# Altium schematic sheet a0141b0_CSS Moonlight AS7265x_scm01.SchDoc
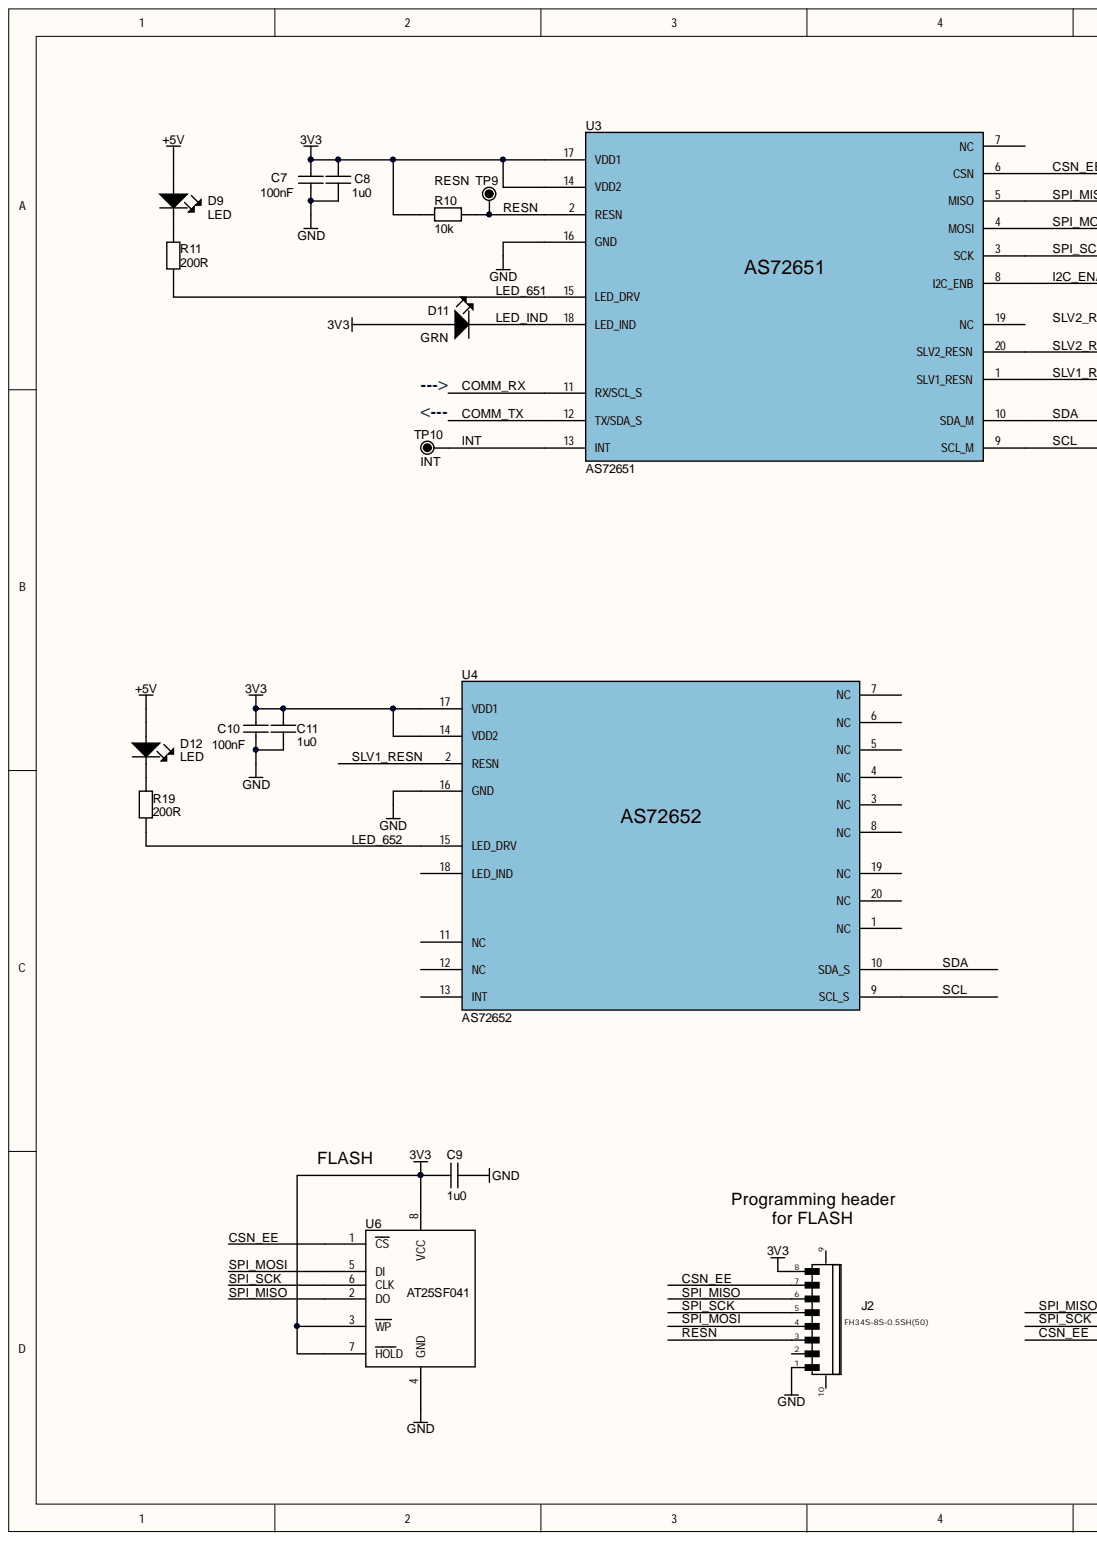
[diagram p0: a0141b0_CSS Moonlight AS7265x_scm01.SchDoc, left]
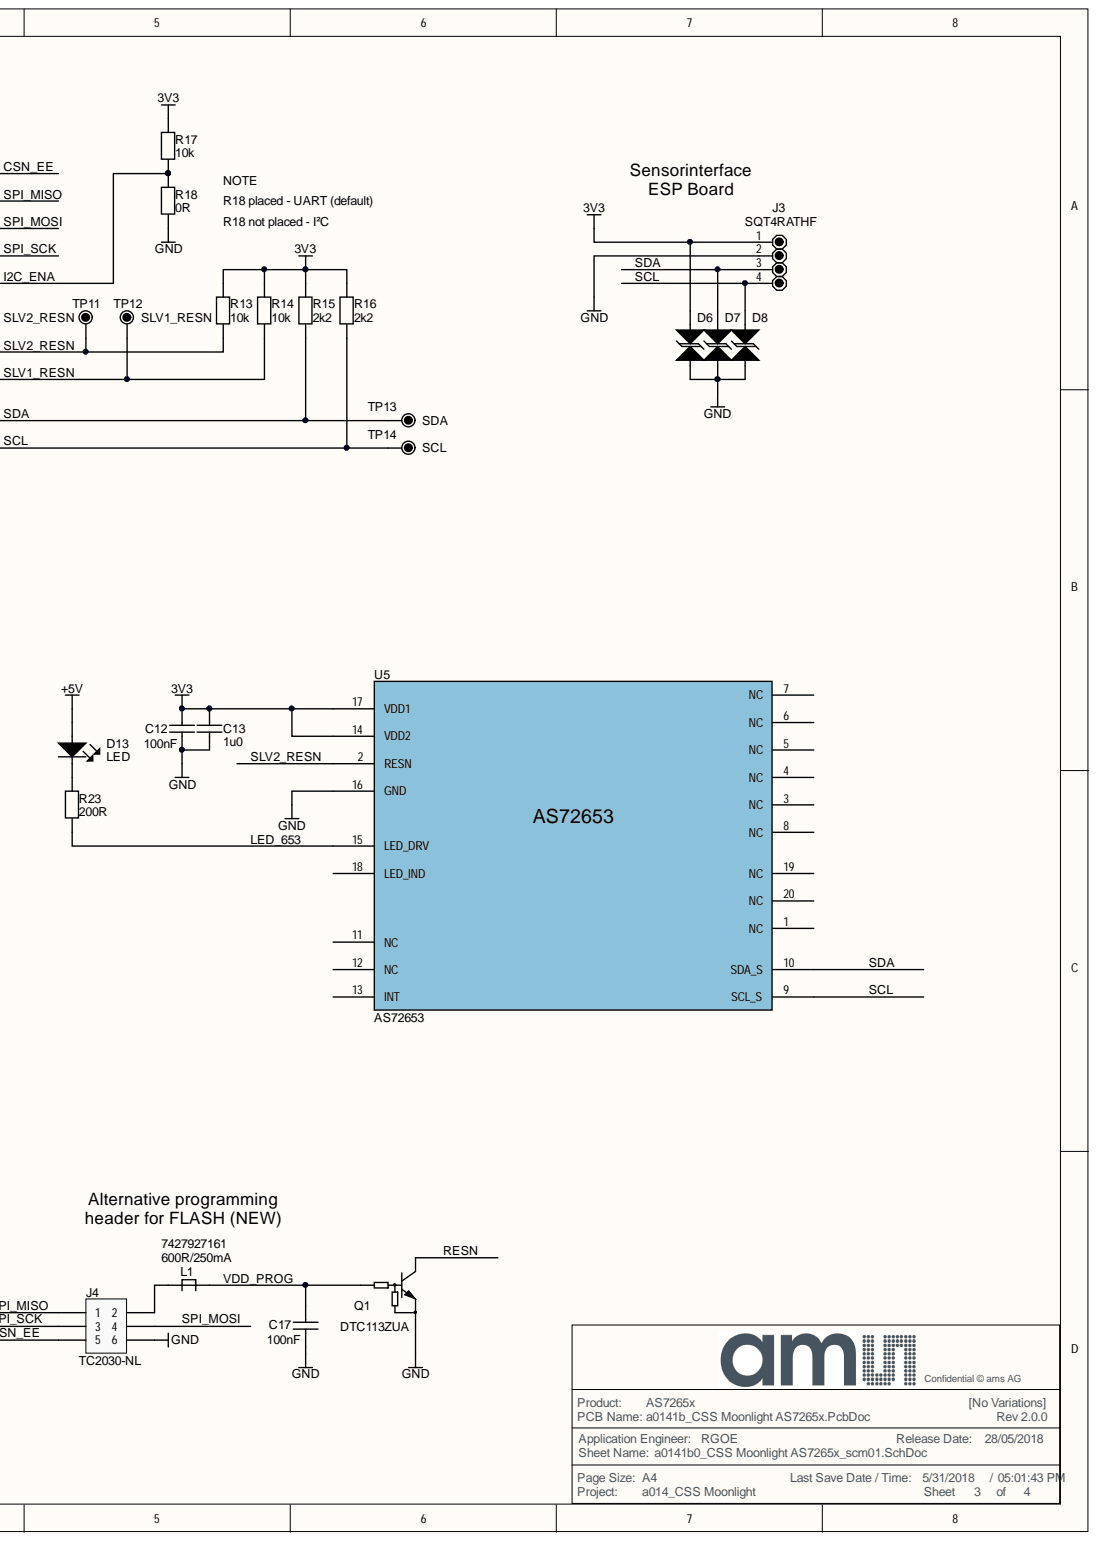
[diagram p1: a0141b0_CSS Moonlight AS7265x_scm01.SchDoc, right]

## Parts
C7  100nF  [C0402]
C8  1u0  [C0402]
C9  1u0  [C0402]
C10  100nF  [C0402]
C11  1u0  [C0402]
C12  100nF  [C0402]
C13  1u0  [C0402]
C17  100nF  [C0402]
D6  D_Suppressor_bip  [RW9_0402]  (value not drawn)
D7  D_Suppressor_bip  [RW9_0402]  (value not drawn)
D8  D_Suppressor_bip  [RW9_0402]  (value not drawn)
D9  LED  [LED_1206]
D11  GRN  [LED_osram_minitop_RG]
D12  LED  [LED_1206]
D13  LED  [LED_1206]
J2  FH34S-8S-0.5SH  value FH34S-8S-0.5SH(50)  [FH34S-8S-0.5SH-RG]
J3  SQT4RATHF  [SQT4RATH]
J4  TC2030-NL  [TC2030-NL]  (no BOM)
L1  600R/250mA  Manufacturer Part Number 1: 7427927161  [WE-CBF_0402_Wide Band]
Q1  DTC113ZUA  [SOT65P210X100-3L]
R10  10k  [R0402]
R11  200R  [R0402]
R13  10k  [R0402]
R14  10k  [R0402]
R15  2k2  [R0402]
R16  2k2  [R0402]
R17  10k  [R0402]
R18  0R  [R0402]
R19  200R  [R0402]
R23  200R  [R0402]
TP9  RESN  [TP-30/44-C]  (no BOM)
TP10  INT  [TP-30/44-C]  (no BOM)
TP11  SLV2_RESN  [TP-30/44-C]  (no BOM)
TP12  SLV1_RESN  [TP-30/44-C]  (no BOM)
TP13  SDA  [TP-30/44-C]  (no BOM)
TP14  SCL  [TP-30/44-C]  (no BOM)
U3  AS72651  [LGA2_SCOTTY]
U4  AS72652  [LGA2_SCOTTY]
U5  AS72653  [LGA2_SCOTTY]
U6  AT25SF041  Memory Type: FLASH  [SO8_narrow]

## Nets
3V3: C7.1 C8.1 C9.1 C10.1 C11.1 C12.1 C13.1 D6.2 D11.2 J2.8 J3.1 R10.1 R13.2 R14.2 R15.2 R16.2 R17.2 U3.14(VDD2) U3.17(VDD1) U4.14(VDD2) U4.17(VDD1) U5.14(VDD2) U5.17(VDD1) U6.3(WP) U6.7(HOLD) U6.8(VCC)
+5V: D9.2 D12.2 D13.2
GND: C7.2 C8.2 C9.2 C10.2 C11.2 C12.2 C13.2 C17.2 D6.1 D7.1 D8.1 J2.1 J3.2 J4.6 Q1.2 R18.1 U3.16(GND) U4.16(GND) U5.16(GND) U6.4(GND)
COMM_RX: U3.11(RX/SCL_S)
COMM_TX: U3.12(TX/SDA_S)
CSN_EE: J2.7 J4.5 U3.6(CSN) U6.1(CS)
I2C_ENA: R17.1 R18.2 U3.8(I2C_ENB)
INT: TP10.1 U3.13(INT)
LED_651: R11.1 U3.15(LED_DRV)
LED_652: R19.1 U4.15(LED_DRV)
LED_653: R23.1 U5.15(LED_DRV)
LED_IND: D11.1 U3.18(LED_IND)
RESN: J2.3 Q1.3 R10.2 TP9.1 U3.2(RESN)
SCL: D8.2 J3.4 R16.1 TP14.1 U3.9(SCL_M) U4.9(SCL_S) U5.9(SCL_S)
SDA: D7.2 J3.3 R15.1 TP13.1 U3.10(SDA_M) U4.10(SDA_S) U5.10(SDA_S)
SLV1_RESN: R14.1 TP12.1 U3.1(SLV1_RESN) U4.2(RESN)
SLV2_RESN: R13.1 TP11.1 U3.20(SLV2_RESN) U5.2(RESN)
SPI_MISO: J2.6 J4.1 U3.5(MISO) U6.2(DO)
SPI_MOSI: J2.4 J4.4 U3.4(MOSI) U6.5(DI)
SPI_SCK: J2.5 J4.3 U3.3(SCK) U6.6(CLK)
VDD_PROG: C17.1 L1.2 Q1.1
NetD9_1: D9.1 R11.2
NetD12_1: D12.1 R19.2
NetD13_1: D13.1 R23.2
NetJ4_2: J4.2 L1.1

Not connected: J2 2 9 10; U3 7(NC) 19(NC); U4 1(NC) 3(NC) 4(NC) 5(NC) 6(NC) 7(NC) 8(NC) 11(NC) 12(NC) 13(INT) 18(LED_IND) 19(NC) 20(NC); U5 1(NC) 3(NC) 4(NC) 5(NC) 6(NC) 7(NC) 8(NC) 11(NC) 12(NC) 13(INT) 18(LED_IND) 19(NC) 20(NC)

## Library data of the parts
C7 C10 C12 C17 = C_0402_100nF: Component Kind: Standard; Supplier 1: Digi-Key; Supplier Part Number 1: 490-3261-1-ND; Library Name: a0141a0_CSS Moonlight AS7265x.SCHLIB
C8 C9 C11 C13 = C_0402_1u0: Component Kind: Standard; Supplier 1: Digi-Key; Supplier Part Number 1: 445-4114-1-ND; Library Name: a0141a0_CSS Moonlight AS7265x.SCHLIB
D6-D8 = D_Suppressor_bip: PGB1010402KR; Component Kind: Standard; Supplier 1: Digi-Key; Supplier Part Number 1: F2862CT-ND; Library Name: a0141a0_CSS Moonlight AS7265x.SCHLIB
D11 = LED_LG L29K-F2J1-24-Z  _1: Component Kind: Standard; Supplier 1: RSComponents; Supplier Part Number 1: 6544304; Library Name: a0141a0_CSS Moonlight AS7265x.SCHLIB
J2 = FH34S-8S-0.5SH: PART DESCRIPTION: Con Flex FFC/FPC 0.5mm ZIF Top Contact,1mm height, 1r 8p RA SMT; Component Kind: Standard; Supplier 1: Digi-Key; Supplier Part Number 1: HFT108CT-ND; Library Name: a0141a0_CSS Moonlight AS7265x.SCHLIB
J3 = SQT4RATHF: CON,2mm,TH,RA,FEMALE,4p; Component Kind: Standard; Supplier 1: Samtec; Supplier 2: Digi-Key; Supplier Part Number 1: SQT-104-01-F-S-RA; Supplier Part Number 2: SAM10202-ND; Library Name: a0141a0_CSS Moonlight AS7265x.SCHLIB
J4 = TC2030-NL: 6 Position TAG-Connect direct Header; Library Name: connectors.SchLib; Supplier 1: www.tag-connect.com; Supplier Part Number 1: TC2030-NL; Value: TC2030-NL
L1 = WE-CBF 7427927161: FERRITE BEAD 600 OHM 0402 1LN; Manufacturer 1: Wurth; Component Kind: Standard; Library Name: passive.SchLib; Supplier 1: Digi-Key; Supplier 2: Farnell; Supplier Part Number 1: 732-2387-1-ND; Supplier Part Number 2: 1961693; Value: 600R/250mA
Q1 = DTC113ZUAT106: Pre-Biased Bipolar Transistor (BJT) NPN - Pre-Biased 50V 100mA 250MHz 200mW Surface Mount UMT3; Component Kind: Standard; Library Name: active.SchLib; Supplier 1: Digi-Key; Supplier 2: Mouser; Supplier Part Number 1: DTC113ZUAT106CT-ND; Supplier Part Number 2: 755-DTC113ZUAT106; Value: DTC113ZUA
R10 R13 R14 R17 = R_10k: Component Kind: Standard; Supplier 1: Digi-Key; Supplier Part Number 1: P10KDECT-ND; Library Name: a0141a0_CSS Moonlight AS7265x.SCHLIB
R11 R19 R23 = R_200R: Component Kind: Standard; Supplier 1: RSComponents; Supplier Part Number 1: 6788949; Library Name: a0141a0_CSS Moonlight AS7265x.SCHLIB
R15 R16 = R_2k2: Component Kind: Standard; Supplier 1: RSComponents; Supplier Part Number 1: 812-1644; Library Name: a0141a0_CSS Moonlight AS7265x.SCHLIB
R18 = R_0R: Component Kind: Standard; Supplier 1: Digi-Key; Supplier Part Number 1: 478-4709-1-ND; Library Name: a0141a0_CSS Moonlight AS7265x.SCHLIB
TP9-TP14 = TP-30-C: Library Name: a0141a0_CSS Moonlight AS7265x.SCHLIB
U3 = AS72651: Smart 6-Channel NIR Spectral_ID Sensor; Component Kind: Standard; Supplier 1: AMS; Supplier Part Number 1: 191960052; Library Name: a0141b0_CSS Moonlight AS7265x.SCHLIB
U4 = AS72652: Smart 6-Channel Visible & NIR Spectral_ID Sensor; Component Kind: Standard; Supplier 1: AMS; Supplier Part Number 1: 191960054; Library Name: a0141b0_CSS Moonlight AS7265x.SCHLIB
U5 = AS72653: Smart 6-Channel Visible Spectral_ID Sensor with Electronic Shutter; Component Kind: Standard; Supplier 1: AMS; Supplier Part Number 1: 191960056; Library Name: a0141b0_CSS Moonlight AS7265x.SCHLIB
U6 = M95M02-DR: Interface: SPI Serial; Interface Type: SPI; Memory Size: 2M (256K x 8); Component Kind: Standard; Description: M95M02-DR; Manufacturer Part Number: M95M02-DR; Mounting Style: SMD/SMT; Supplier 1: Digi-Key; Supplier Part Number 1: 1265-1174-5-ND; Library Name: a0141a0_CSS Moonlight AS7265x.SCHLIB
D9 D12 D13 = LED: Component Kind: Standard; Supplier 1: RSComponents; Supplier Part Number 1: 6544304; Library Name: a0141a0_CSS Moonlight AS7265x.SCHLIB
